# Altium schematic sheet LCD_IF.SchDoc(LCD_IF)
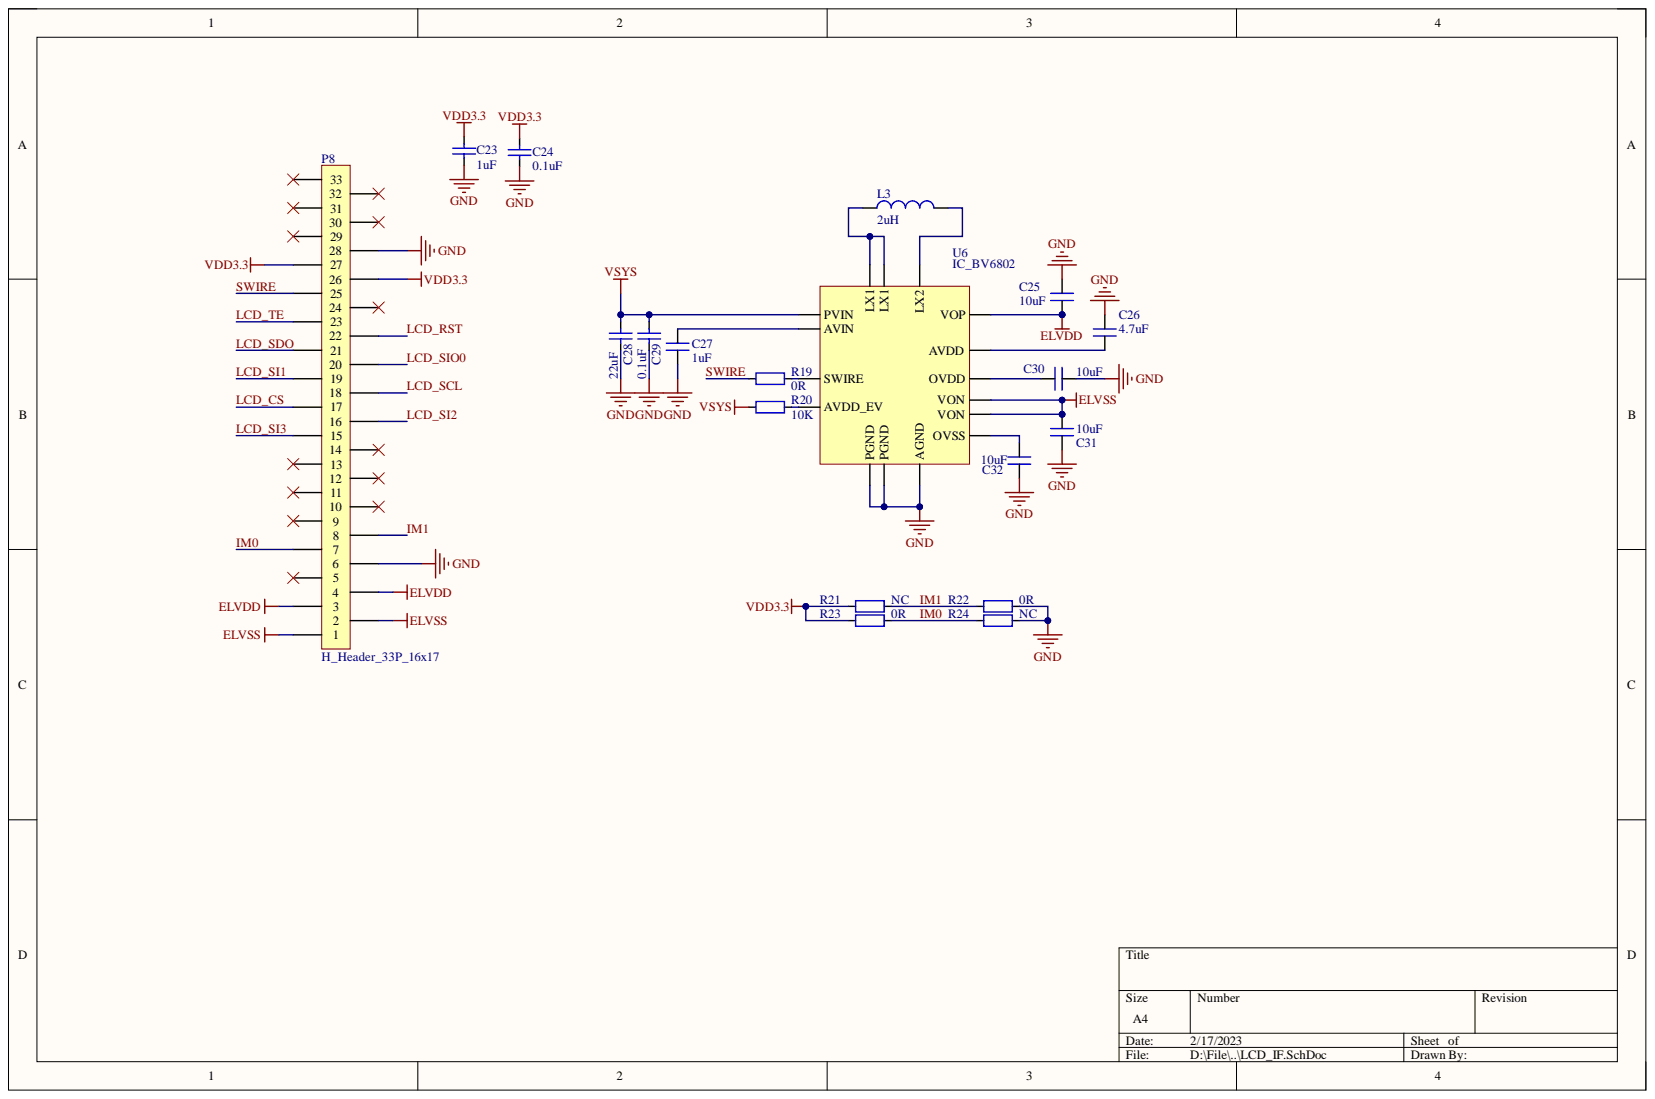
[diagram p0: LCD_IF.SchDoc]

## Parts
C23  1uF  [CAP_0402]
C24  0.1uF  [CAP_0402]
C25  10uF  [CAP_0402]
C26  4.7uF  [CAP_0402]
C27  1uF  [CAP_0402]
C28  22uF  [CAP_0603]
C29  0.1uF  [CAP_0402]
C30  10uF  [CAP_0402]
C31  10uF  [CAP_0402]
C32  10uF  [CAP_0402]
L3  2uH  [L_SMT_2010]
P8  H_Header_33P_16x17  [FPC_33P_16x17]
R19  0R  [RES_0402]
R20  10K  [RES_0402]
R21  NC  [RES_0805]
R22  0R  [RES_0805]
R23  0R  [RES_0805]
R24  NC  [RES_0805]
U6  IC_BV6802  [WLCSP-16]

## Nets
ELVDD: C25.2 P8.3 P8.4 U6.D1(VOP)
ELVSS: C31.1 P8.1 P8.2 U6.A3(VON) U6.B3(VON)
GND: C23.1 C24.1 C25.1 C26.1 C27.2 C28.1 C29.1 C30.2 C31.2 C32.2 P8.6 P8.28 R22.1 R24.1 U6.C2(PGND) U6.C3(AGND) U6.D2(PGND)
VDD3.3: C23.2 C24.2 P8.26 P8.27 R21.2 R23.2
VSYS: C28.2 C29.2 R20.1 U6.A1(PVIN)
IM0: P8.7 R23.1 R24.2
IM1: P8.8 R21.1 R22.2
LCD_CS: P8.17
LCD_RST: P8.22
LCD_SCL: P8.18
LCD_SDO: P8.21
LCD_SI1: P8.19
LCD_SI2: P8.16
LCD_SI3: P8.15
LCD_SIO0: P8.20
LCD_TE: P8.23
SWIRE: P8.25 R19.2
NetC26_2: C26.2 U6.D4(AVDD)
NetC27_1: C27.1 U6.A2(AVIN)
NetC30_1: C30.1 U6.D3(OVDD)
NetC32_1: C32.1 U6.A4(OVSS)
NetL3_1: L3.1 U6.B1(LX1) U6.B2(LX1)
NetL3_2: L3.2 U6.C1(LX2)
NetR19_1: R19.1 U6.B4(SWIRE)
NetR20_2: R20.2 U6.C4(AVDD_EV)

Not connected: P8 5 9 10 11 12 13 14 24 29 30 31 32 33

## Library data of the parts
C23 C24 C28 C29 = A_Capacitor_1: Ceramics Capacitor; Component Kind: Standard; Library Name: SCH_Lib.SchLib; Signal Integrity: CAPACITOR; Simulation: CAPACITOR
C25-C27 C30-C32 = C: Component Kind: Standard; Library Name: 光学蓝牙.SCHLIB
L3 = Inductor: Code_IEC: 1005; Code_JEITA: 0402; Component Kind: Standard; Description: Inductor; LatestRevisionDate: 29-May-2009; LatestRevisionNote: IPC-7351 Footprint Added.; Library Name: Miscellaneous Devices.IntLib; PackageDescription: Chip Inductor; PackageReference: 0402-A; Published: 8-Jun-2000; Signal Integrity: Inductor; Simulation: INDUCTOR; Value: 2uH; Publisher: Altium Limited
R19-R24 = A_Resistor_1: Normal Resistor; Component Kind: Standard; Library Name: SCH_Lib.SchLib; Signal Integrity: RESISTOR; Simulation: RESISTOR
U6 = IC_BV6804: Component Kind: Standard; Library Name: Micky.SchLib
P8 = H_Header_33P_16x17: Component Kind: Standard; Library Name: Micky.SchLib
